# Altium schematic sheet PDM.SchDoc
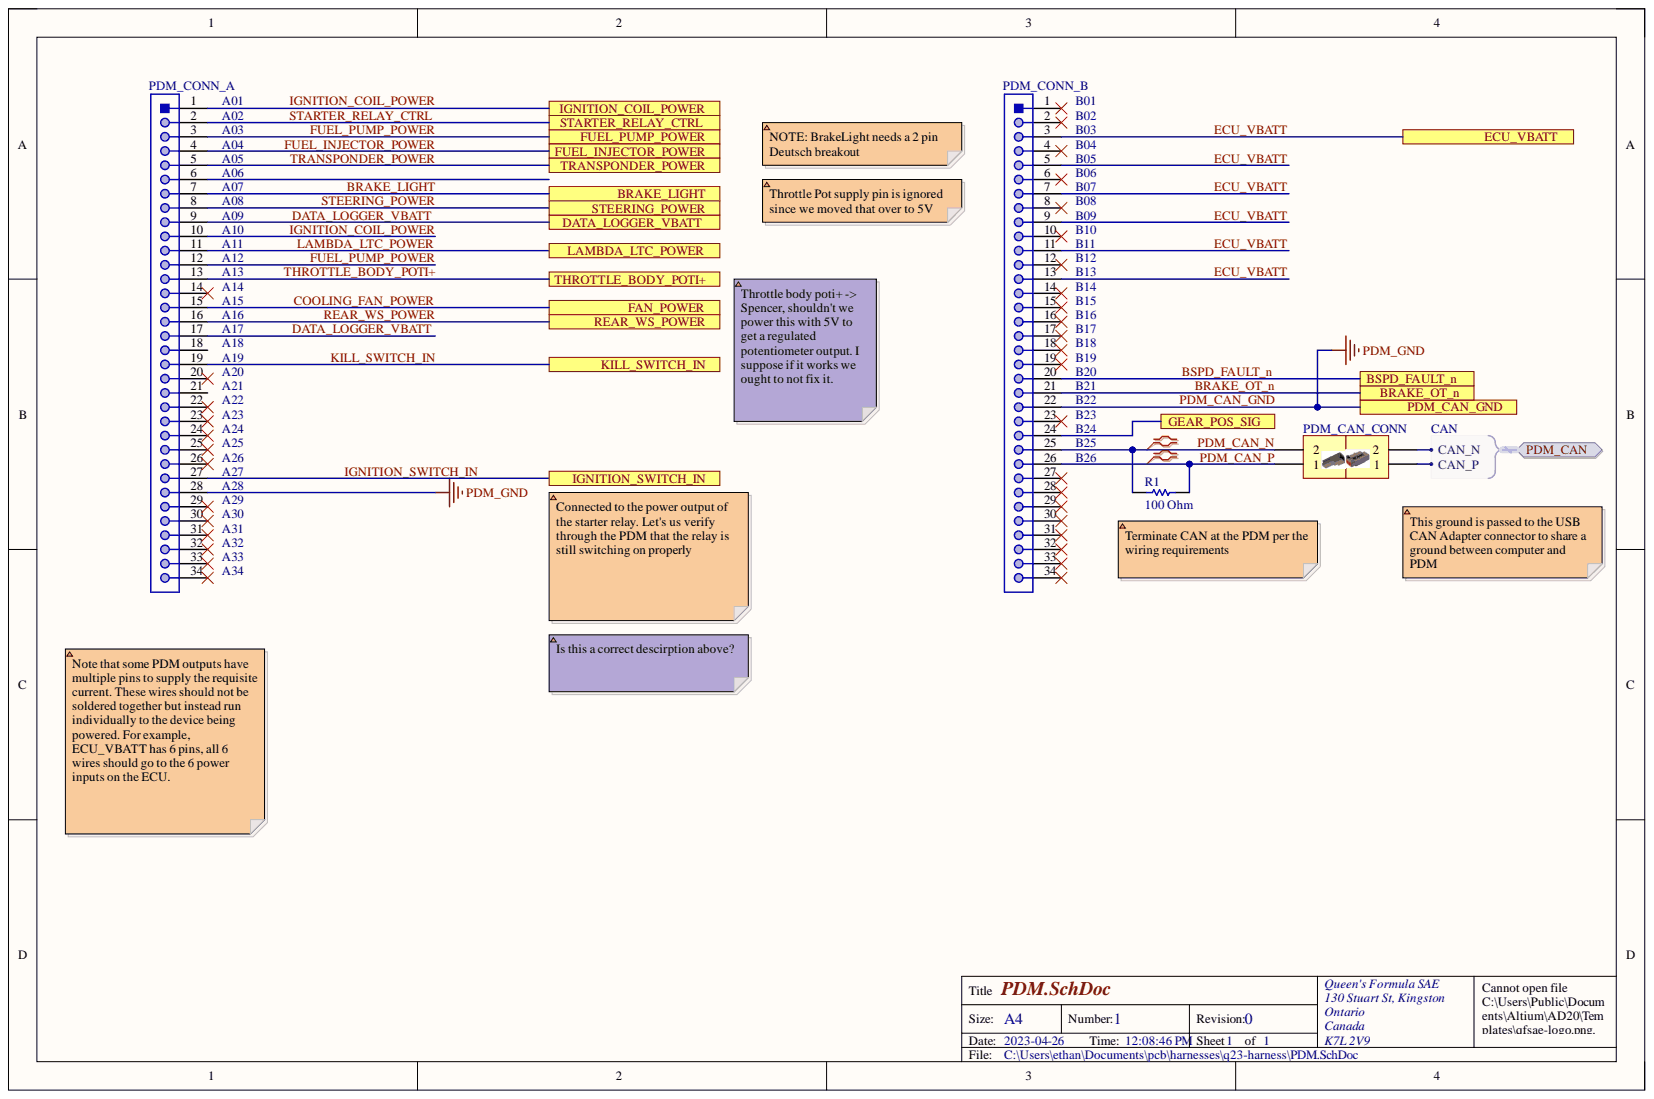
[diagram p0: PDM.SchDoc]

## Parts
PDM_CAN_CONN  Header 2  [HDR1X2]  (value not drawn)
PDM_CAN_CONN  Header 2  [HDR1X2]  (value not drawn)
PDM_CONN_A  61303411021  [61303411021]  (value not drawn)
PDM_CONN_B  61303411021  [61303411021]  (value not drawn)
R1  100 Ohm  [RESC3216X70X50NL05T20]

## Ports
BRAKE_LIGHT, BRAKE_OT_n, BSPD_FAULT_n, DATA_LOGGER_VBATT, ECU_VBATT, FAN_POWER, FUEL_INJECTOR_POWER, FUEL_PUMP_POWER, GEAR_POS_SIG, IGNITION_COIL_POWER, IGNITION_SWITCH_IN, KILL_SWITCH_IN, LAMBDA_LTC_POWER, PDM_CAN, PDM_CAN.CAN_N, PDM_CAN.CAN_P, PDM_CAN_GND, REAR_WS_POWER, STARTER_RELAY_CTRL, STEERING_POWER, THROTTLE_BODY_POTI+, TRANSPONDER_POWER

## Nets
BRAKE_LIGHT: PDM_CONN_A.7 ; port BRAKE_LIGHT
BRAKE_OT_n: PDM_CONN_B.21 ; port BRAKE_OT_n
BSPD_FAULT_n: PDM_CONN_B.20 ; port BSPD_FAULT_n
COOLING_FAN_POWER: PDM_CONN_A.15 ; port FAN_POWER
DATA_LOGGER_VBATT: PDM_CONN_A.9 PDM_CONN_A.17 ; port DATA_LOGGER_VBATT
ECU_VBATT: PDM_CONN_B.3 PDM_CONN_B.5 PDM_CONN_B.7 PDM_CONN_B.9 PDM_CONN_B.11 PDM_CONN_B.13 ; port ECU_VBATT
FUEL_INJECTOR_POWER: PDM_CONN_A.4 ; port FUEL_INJECTOR_POWER
FUEL_PUMP_POWER: PDM_CONN_A.3 PDM_CONN_A.12 ; port FUEL_PUMP_POWER
GEAR_POS_SIG: PDM_CONN_B.24 ; port GEAR_POS_SIG
IGNITION_COIL_POWER: PDM_CONN_A.1 PDM_CONN_A.10 ; port IGNITION_COIL_POWER
IGNITION_SWITCH_IN: PDM_CONN_A.27 ; port IGNITION_SWITCH_IN
KILL_SWITCH_IN: PDM_CONN_A.19 ; port KILL_SWITCH_IN
LAMBDA_LTC_POWER: PDM_CONN_A.11 ; port LAMBDA_LTC_POWER
PDM_CAN_GND: PDM_CONN_A.28 PDM_CONN_B.22 ; port PDM_CAN_GND
PDM_CAN_N: PDM_CAN_CONN.2 PDM_CONN_B.25 R1.1
PDM_CAN_P: PDM_CAN_CONN.1 PDM_CONN_B.26 R1.2
REAR_WS_POWER: PDM_CONN_A.16 ; port REAR_WS_POWER
STARTER_RELAY_CTRL: PDM_CONN_A.2 ; port STARTER_RELAY_CTRL
STEERING_POWER: PDM_CONN_A.8 ; port STEERING_POWER
THROTTLE_BODY_POTI+: PDM_CONN_A.13 ; port THROTTLE_BODY_POTI+
TRANSPONDER_POWER: PDM_CONN_A.5 ; port TRANSPONDER_POWER
NetPDM_CAN_CONN_1: PDM_CAN_CONN.1 ; port PDM_CAN
NetPDM_CAN_CONN_2: PDM_CAN_CONN.2 ; port PDM_CAN

Not connected: PDM_CONN_A 6 14 18 20 21 22 23 24 25 26 29 30 31 32 33 34; PDM_CONN_B 1 2 4 6 8 10 12 14 15 16 17 18 19 23 27 28 29 30 31 32 33 34

## Library data of the parts
PDM_CAN_CONN PDM_CAN_CONN = Header 2: Header, 2-Pin; Component Kind: Standard; LatestRevisionDate: 17-Jul-2002; LatestRevisionNote: Re-released for DXP Platform.; Library Name: Miscellaneous Connectors.IntLib; Signal Integrity: Connector; Publisher: Altium Limited
PDM_CONN_A PDM_CONN_B = CMP-1502-01125-1: THT Angled Pin Header WR-PHD, Pitch 2.54 mm, Single Row, 34 pins; Manufacturer: Wurth Elektronik; Contact Resistance: 20mOhm; Gender: Male; Pin Number: 34; Pitch: 2.54mm; Rated Current: 3A; Withstanding Voltage: 500VAC; Working Voltage: 250VAC; Component Kind: Standard; Datasheet URL: https://katalog.we-online.de/em/datasheet/6130xx11021.pdf; Library Name: Altium Content Vault; Manufacturer URL: http://www.we-online.de; Mounting Technology: THT; Operating Temperature: -40to105degC; Orientation: Angled; Package Reference: 61303411021; Packaging: Bag; Part Number: 61303411021; Row Number: Single; Publisher: WurthElektronik
R1 = CMP-2003-00016-1: Manufacturer: Panasonic; Part Number: ERJ-8ENF1000V; Component Kind: Standard; Library Name: Altium Content Vault
